# Altium schematic sheet HARRY.SchDoc
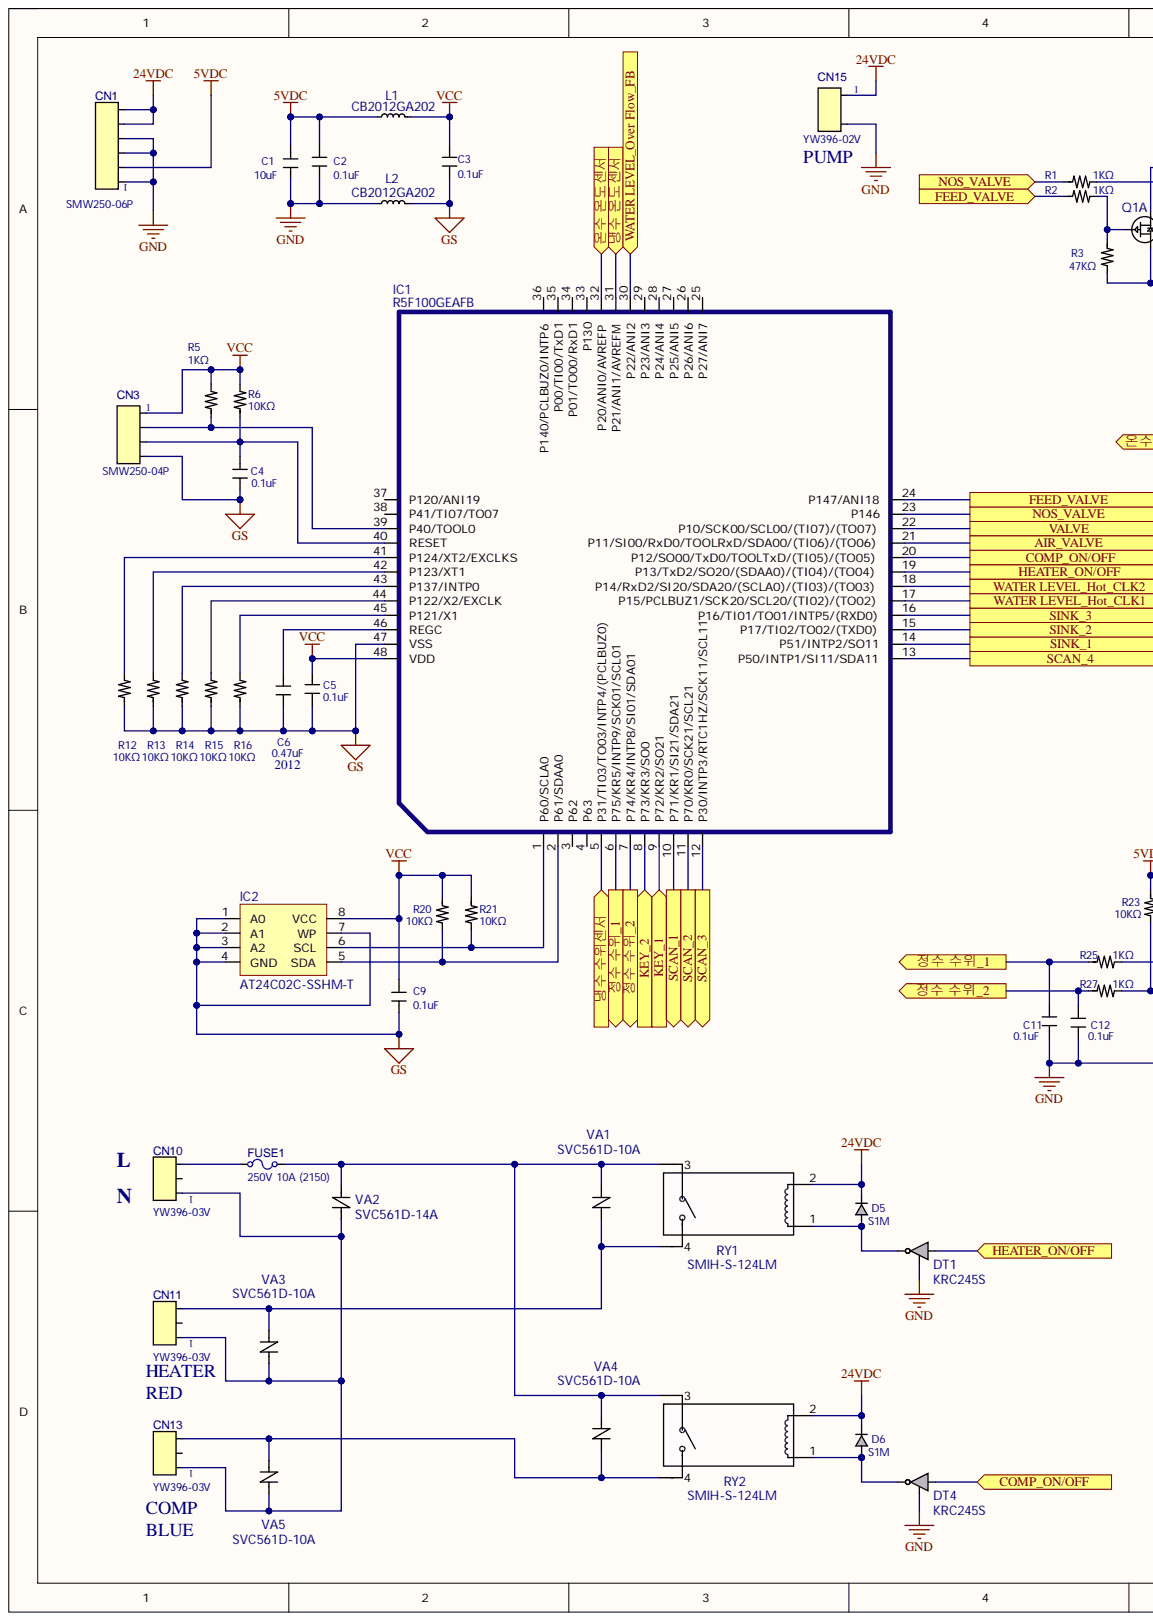
[diagram p0: HARRY.SchDoc, left]
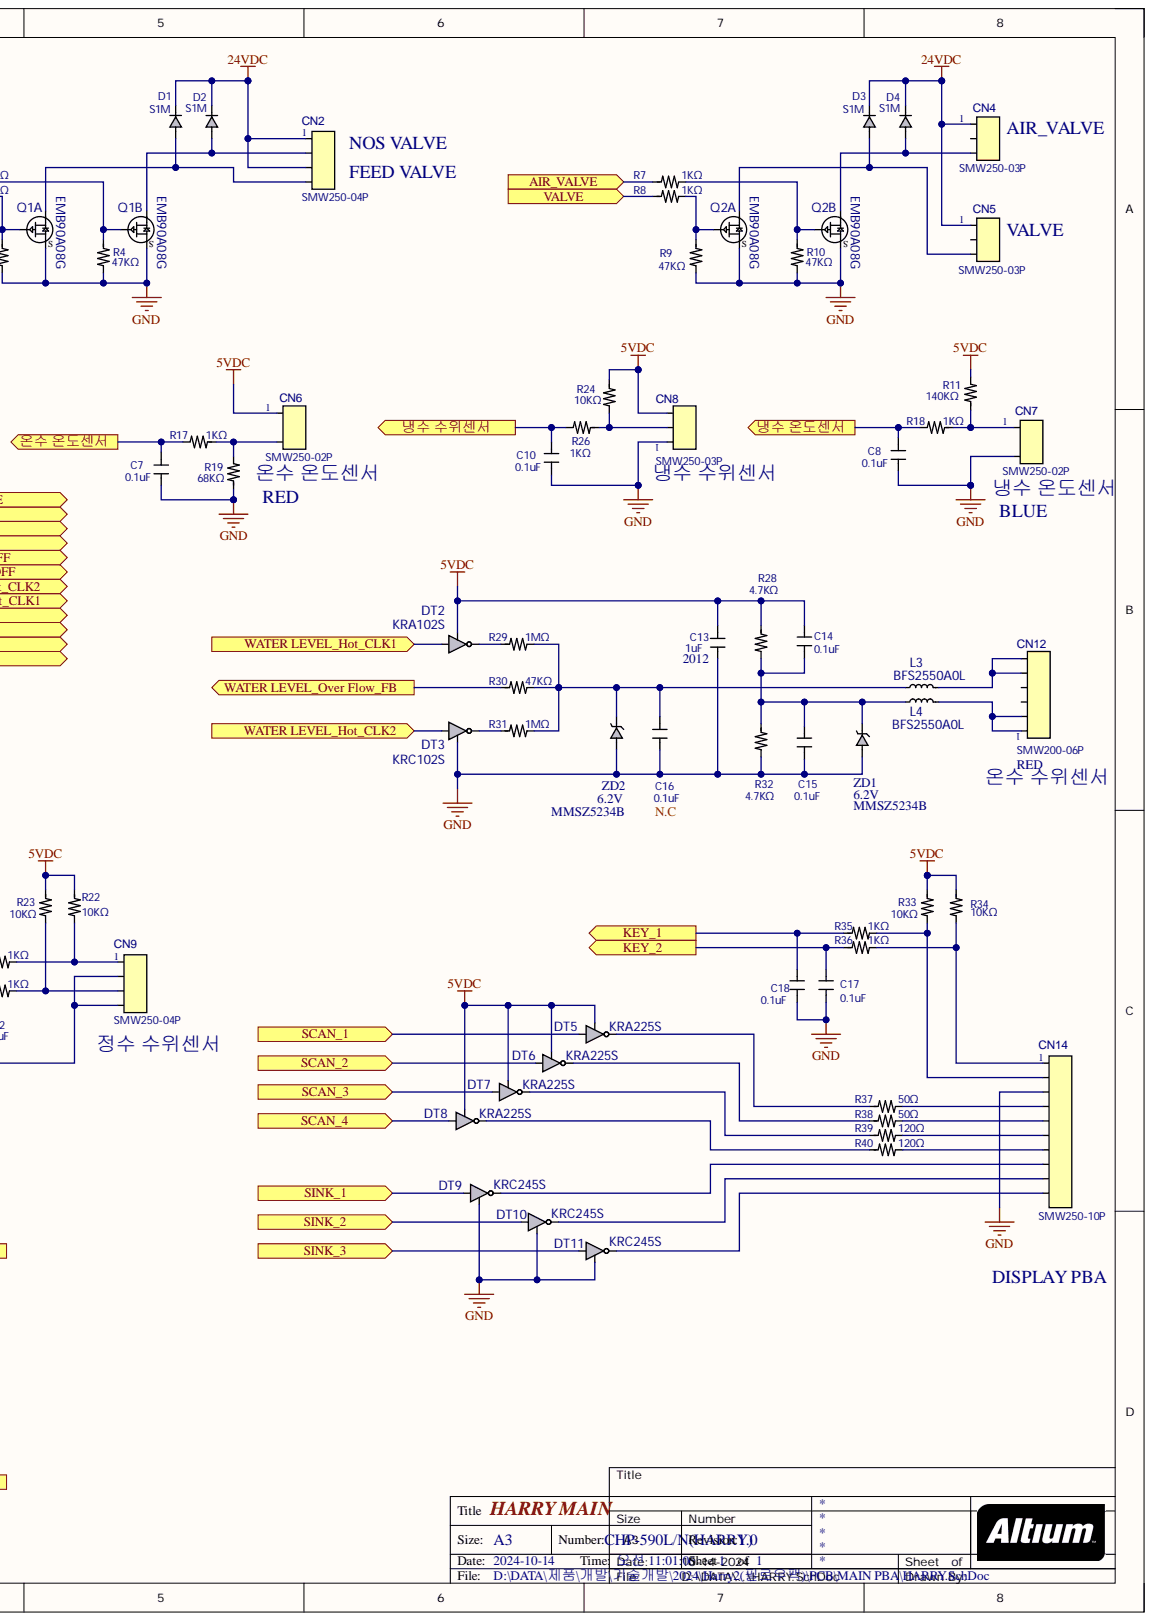
[diagram p1: HARRY.SchDoc, right]

## Parts
C1  10uF  [C-SMD-3216]
C2  0.1uF  [C-SMD-1608]
C3  0.1uF  [C-SMD-1608]
C4  0.1uF  [C-SMD-1608]
C5  0.1uF  [C-SMD-1608]
C6  0.47uF  [C-SMD-2012]
C7  0.1uF  [C-SMD-1608]
C8  0.1uF  [C-SMD-1608]
C9  0.1uF  [C-SMD-1608]
C10  0.1uF  [C-SMD-1608]
C11  0.1uF  [C-SMD-1608]
C12  0.1uF  [C-SMD-1608]
C13  1uF  13.PKG: 2012  [C-SMD-2012]
C14  0.1uF  [C-SMD-1608]
C15  0.1uF  [C-SMD-1608]
C16  0.1uF  [C-SMD-1608]
C17  0.1uF  [C-SMD-1608]
C18  0.1uF  [C-SMD-1608]
CN1  SMW250-06P  [CN-SMW250-06-06P-2_5P-174058-070H]
CN2  SMW250-04P  [CN-SMW250-04-04P-2_5P-124058-070H]
CN3  SMW250-04P  [CN-SMW250-04-04P-2_5P-124058-070H]
CN4  SMW250-03P  [CN-SMW250-03-03P-2_5P-099058-070H]
CN5  SMW250-03P  [CN-SMW250-03-03P-2_5P-099058-070H]
CN6  SMW250-02P  [CN-SMW250-02-02P-2_5P-074058-070H]
CN7  SMW250-02P  [CN-SMW250-02-02P-2_5P-074058-070H]
CN8  SMW250-03P  [CN-SMW250-03-03P-2_5P-099058-070H]
CN9  SMW250-04P  [CN-SMW250-04-04P-2_5P-124058-070H]
CN10  YW396-03V  [CN-YW396-03V-03P-3_96P-118081-111H]
CN11  YW396-03V  [CN-YW396-03V-03P-3_96P-118081-111H]
CN12  SMW200-06P  14.Color: RED  [CN-SMW200-6P-06P-2_0P-140051-077H]
CN13  YW396-03V  [CN-YW396-03V-03P-3_96P-118081-111H]
CN14  SMW250-10P  [CN-SMW250-10-10P-2_5P-274058-070H]
CN15  YW396-02V  [CN-YW396-02V-02P-3_96P-078081-111H]
D1  S1M  [D_DO-214AC]
D2  S1M  [D_DO-214AC]
D3  S1M  [D_DO-214AC]
D4  S1M  [D_DO-214AC]
D5  S1M  [D_DO-214AC]
D6  S1M  [D_DO-214AC]
DT1  KRC245S  [TR-SOT-23_BCE-3P-1_0P-031027-013H]
DT2  KRA102S  [TR-SOT-23_BCE-3P-1_0P-031027-013H]
DT3  KRC102S  [TR-SOT-23_BCE-3P-1_0P-031027-013H]
DT4  KRC245S  [TR-SOT-23_BCE-3P-1_0P-031027-013H]
DT5  KRA225S  [TR-SOT-23_BCE-3P-1_0P-031027-013H]
DT6  KRA225S  [TR-SOT-23_BCE-3P-1_0P-031027-013H]
DT7  KRA225S  [TR-SOT-23_BCE-3P-1_0P-031027-013H]
DT8  KRA225S  [TR-SOT-23_BCE-3P-1_0P-031027-013H]
DT9  KRC245S  [TR-SOT-23_BCE-3P-1_0P-031027-013H]
DT10  KRC245S  [TR-SOT-23_BCE-3P-1_0P-031027-013H]
DT11  KRC245S  [TR-SOT-23_BCE-3P-1_0P-031027-013H]
FUSE1  250V 10A (2150)  [FUSE_20MM]
IC1  R5F100GEAFB  [IC-LQFP48P-0_48P]
IC2  AT24C02C-SSHM-T  [IC-SOP08P-1_27P-046058-175H]
L1  CB2012GA202  [L_2012SMD]
L2  CB2012GA202  [L_2012SMD]
L3  BFS2550A0L  [New footprint 1]
L4  BFS2550A0L  [New footprint 1]
Q1  EMB90A08G  [TR-SOP08P-1_27P-046058-175H]  (parts A,B)
Q2  EMB90A08G  [TR-SOP08P-1_27P-046058-175H]  (parts A,B)
R1  1KΩ  [R-SMD-1608-045H]
R2  1KΩ  [R-SMD-1608-045H]
R3  47KΩ  [R-SMD-1608-045H]
R4  47KΩ  [R-SMD-1608-045H]
R5  1KΩ  [R-SMD-1608-045H]
R6  10KΩ  [R-SMD-1608-045H]
R7  1KΩ  [R-SMD-1608-045H]
R8  1KΩ  [R-SMD-1608-045H]
R9  47KΩ  [R-SMD-1608-045H]
R10  47KΩ  [R-SMD-1608-045H]
R11  140KΩ  [R-SMD-1608-045H]
R12  10KΩ  [R-SMD-1608-045H]
R13  10KΩ  [R-SMD-1608-045H]
R14  10KΩ  [R-SMD-1608-045H]
R15  10KΩ  [R-SMD-1608-045H]
R16  10KΩ  [R-SMD-1608-045H]
R17  1KΩ  [R-SMD-1608-045H]
R18  1KΩ  [R-SMD-1608-045H]
R19  68KΩ  [R-SMD-1608-045H]
R20  10KΩ  [R-SMD-1608-045H]
R21  10KΩ  [R-SMD-1608-045H]
R22  10KΩ  [R-SMD-1608-045H]
R23  10KΩ  [R-SMD-1608-045H]
R24  10KΩ  [R-SMD-1608-045H]
R25  1KΩ  [R-SMD-1608-045H]
R26  1KΩ  [R-SMD-1608-045H]
R27  1KΩ  [R-SMD-1608-045H]
R28  4.7KΩ  [R-SMD-1608-045H]
R29  1MΩ  [R-SMD-1608-045H]
R30  47KΩ  [R-SMD-1608-045H]
R31  1MΩ  [R-SMD-1608-045H]
R32  4.7KΩ  [R-SMD-1608-045H]
R33  10KΩ  [R-SMD-1608-045H]
R34  10KΩ  [R-SMD-1608-045H]
R35  1KΩ  [R-SMD-1608-045H]
R36  1KΩ  [R-SMD-1608-045H]
R37  50Ω  [R-SMD-3216-055H]
R38  50Ω  [R-SMD-3216-055H]
R39  120Ω  [R-SMD-3216-055H]
R40  120Ω  [R-SMD-3216-055H]
RY1  SMIH-S-124LM  [OMIH-SS-124LM]
RY2  SMIH-S-124LM  [OMIH-SS-124LM]
VA1  SVC561D-10A  [ZNR_D10]
VA2  SVC561D-14A  [ZNR_D14]
VA3  SVC561D-10A  [ZNR_D10]
VA4  SVC561D-10A  [ZNR_D10]
VA5  SVC561D-10A  [ZNR_D10]
ZD1  MMSZ5234B  11.Vz: 6.2V  [MMSZ5234B-Footprint-1]
ZD2  MMSZ5234B  [MMSZ5234B-Footprint-1]

## Ports
AIR_VALVE, COMP_ON/OFF, FEED_VALVE, HEATER_ON/OFF, KEY_1, KEY_2, NOS_VALVE, SCAN_1, SCAN_2, SCAN_3, SCAN_4, SINK_1, SINK_2, SINK_3, VALVE, WATER LEVEL_Hot_CLK1, WATER LEVEL_Hot_CLK2, WATER LEVEL_Over Flow_FB, 냉수 수위센서, 냉수 온도센서, 온수 온도센서, 정수 수위_1, 정수 수위_2

## Nets
5VDC: C1.2 C2.1 C13.2 C14.1 CN1.2 CN6.1 CN8.3 DT2.E DT5.E DT6.E DT7.E DT8.E L1.1 R11.2 R22.1 R23.1 R24.1 R28.1 R33.1 R34.1
24VDC: CN1.5 CN1.6 CN2.1 CN2.3 CN4.1 CN5.1 CN15.1 D1.C D2.C D3.C D4.C D5.C D6.C RY1.2 RY2.2
GND: C1.1 C2.2 C7.1 C8.1 C10.2 C11.2 C12.1 C13.1 C15.2 C16.1 C17.2 C18.2 CN1.1 CN1.3 CN1.4 CN7.2 CN8.1 CN9.2 CN9.4 CN14.3 CN15.2 DT1.E DT3.E DT4.E DT9.E DT10.E DT11.E L2.1 Q1.1 Q1.3 Q2.1 Q2.3 R3.2 R4.2 R9.2 R10.2 R19.1 R32.2 ZD1.A ZD2.A
GS: C3.2 C4.1 C5.2 C6.1 C9.1 CN3.4 IC1.47(VSS) IC2.1(A0) IC2.2(A1) IC2.3(A2) IC2.4(GND) IC2.7(WP) L2.2 R12.1 R13.1 R14.1 R15.1 R16.1
VCC: C3.1 C5.1 C9.2 CN3.1 IC1.48(VDD) IC2.8(VCC) L1.2 R5.2 R6.2 R20.1 R21.1
AIR_VALVE: IC1.21(P11/SI00/RxD0/TOOLRxD/SDA00/(TI06)/(TO06)) R7.1 ; port AIR_VALVE
COMP_ON/OFF: DT4.B IC1.20(P12/SO00/TxD0/TOOLTxD/(TI05)/(TO05)) ; port COMP_ON/OFF
FEED_VALVE: IC1.24(P147/ANI18) R2.1 ; port FEED_VALVE
HEATER_ON/OFF: DT1.B IC1.19(P13/TxD2/SO20/(SDAA0)/(TI04)/(TO04)) ; port HEATER_ON/OFF
KEY_1: C18.1 IC1.9(P72/KR2/SO21) R35.1 ; port KEY_1
KEY_2: C17.1 IC1.8(P73/KR3/SO0) R36.1 ; port KEY_2
NOS_VALVE: IC1.23(P146) R1.1 ; port NOS_VALVE
SCAN_1: DT5.B IC1.10(P71/KR1/SI21/SDA21) ; port SCAN_1
SCAN_2: DT6.B IC1.11(P70/KR0/SCK21/SCL21) ; port SCAN_2
SCAN_3: DT7.B IC1.12(P30/INTP3/RTC1HZ/SCK11/SCL11) ; port SCAN_3
SCAN_4: DT8.B IC1.13(P50/INTP1/SI11/SDA11) ; port SCAN_4
SINK_1: DT9.B IC1.14(P51/INTP2/SO11) ; port SINK_1
SINK_2: DT10.B IC1.15(P17/TI02/TO02/(TXD0)) ; port SINK_2
SINK_3: DT11.B IC1.16(P16/TI01/TO01/INTP5/(RXD0)) ; port SINK_3
VALVE: IC1.22(P10/SCK00/SCL00/(TI07)/(TO07)) R8.1 ; port VALVE
WATER LEVEL_Hot_CLK1: DT2.B IC1.17(P15/PCLBUZ1/SCK20/SCL20/(TI02)/(TO02)) ; port WATER LEVEL_Hot_CLK1
WATER LEVEL_Hot_CLK2: DT3.B IC1.18(P14/RxD2/SI20/SDA20/(SCLA0)/(TI03)/(TO03)) ; port WATER LEVEL_Hot_CLK2
WATER LEVEL_Over Flow_FB: IC1.30(P22/ANI2) R30.1 ; port WATER LEVEL_Over Flow_FB
정수 수위_1: C11.1 IC1.6(P75/KR5/INTP9/SCK01/SCL01) R25.1 ; port 정수 수위_1
정수 수위_2: C12.2 IC1.7(P74/KR4/INTP8/SI01/SDA01) R27.1 ; port 정수 수위_2
NetC4_2: C4.2 CN3.3 IC1.40(RESET) R6.1
NetC6_2: C6.2 IC1.46(REGC)
NetC7_2: C7.2 IC1.32(P20/ANI0/AVREFP) R17.2
NetC8_2: C8.2 IC1.31(P21/ANI1/AVREFM) R18.2
NetC10_1: C10.1 IC1.5(P31/TI03/TO03/INTP4/(PCLBUZ0)) R26.2
NetC14_2: C14.2 C15.1 L4.1 R28.2 R32.1 ZD1.C
NetC16_2: C16.2 L3.1 R29.2 R30.2 R31.2 ZD2.C
NetCN2_2: CN2.2 D2.A Q1.5
NetCN2_4: CN2.4 D1.A Q1.8
NetCN3_2: CN3.2 IC1.39(P40/TOOL0) R5.1
NetCN4_3: CN4.3 D4.A Q2.5
NetCN5_3: CN5.3 D3.A Q2.8
NetCN6_2: CN6.2 R17.1 R19.2
NetCN7_1: CN7.1 R11.1 R18.1
NetCN8_2: CN8.2 R24.2 R26.1
NetCN9_1: CN9.1 R22.2 R25.2
NetCN9_3: CN9.3 R23.2 R27.2
NetCN10_1: CN10.1 CN11.1 CN13.1 VA2.2 VA3.1 VA5.1
NetCN10_3: CN10.3 FUSE1.1
NetCN11_3: CN11.3 RY1.4 VA1.1 VA3.2
NetCN12_1: CN12.1 CN12.2 L4.2
NetCN12_5: CN12.5 CN12.6 L3.2
NetCN13_3: CN13.3 RY2.4 VA4.1 VA5.2
NetCN14_1: CN14.1 R34.2 R36.2
NetCN14_2: CN14.2 R33.2 R35.2
NetCN14_4: CN14.4 R37.2
NetCN14_5: CN14.5 R38.2
NetCN14_6: CN14.6 R39.2
NetCN14_7: CN14.7 R40.2
NetCN14_8: CN14.8 DT9.C
NetCN14_9: CN14.9 DT10.C
NetCN14_10: CN14.10 DT11.C
NetD5_A: D5.A DT1.C RY1.1
NetD6_A: D6.A DT4.C RY2.1
NetDT2_C: DT2.C R29.1
NetDT3_C: DT3.C R31.1
NetDT5_C: DT5.C R37.1
NetDT6_C: DT6.C R38.1
NetDT7_C: DT7.C R39.1
NetDT8_C: DT8.C R40.1
NetFUSE1_2: FUSE1.2 RY1.3 RY2.3 VA1.2 VA2.1 VA4.2
NetIC1_1: IC1.1(P60/SCLA0) IC2.6(SCL) R21.2
NetIC1_2: IC1.2(P61/SDAA0) IC2.5(SDA) R20.2
NetIC1_41: IC1.41(P124/XT2/EXCLKS) R12.2
NetIC1_42: IC1.42(P123/XT1) R13.2
NetIC1_43: IC1.43(P137/INTP0) R14.2
NetIC1_44: IC1.44(P122/X2/EXCLK) R15.2
NetIC1_45: IC1.45(P121/X1) R16.2
NetQ1_2: Q1.2 R2.2 R3.1
NetQ1_4: Q1.4 R1.2 R4.1
NetQ2_2: Q2.2 R8.2 R9.1
NetQ2_4: Q2.4 R7.2 R10.1

Not connected: CN4 2; CN5 2; CN10 2; CN11 2; CN12 3 4; CN13 2; IC1 3(P62) 4(P63) 25(P27/ANI7) 26(P26/ANI6) 27(P25/ANI5) 28(P24/ANI4) 29(P23/ANI3) 33(P130) 34(P01/TO00/RxD1) 35(P00/TI00/TxD1) 36(P140/PCLBUZ0/INTP6) 37(P120/ANI19) 38(P41/TI07/TO07)

## Library data of the parts
C1 = CMP-00585-2: 10uF,25V,3216,125℃,+10, -10%,,Reel,; 02.Part Number: 8026573; 01.No: 26432; 03.부품분류: CAPACITOR, MLCC; 13.PKG: 3216; 15.C Tolerance: 10; 11.Capacitance: 10uF; 12.Voltage: 25V; 14.Temperature: 125℃; 16.Pitch: -10%; 21.Value: 10uF; 22.등록일: 2014-02-28; Component Kind: Standard; Library Name: Coway 365
C2 C3 C5 = CMP-00596-2: 0.1uF,50V,1608,125℃,+10, -10%,,Reel,; 02.Part Number: 8026584; 01.No: 26443; 03.부품분류: CAPACITOR, MLCC; 13.PKG: 1608; 15.C Tolerance: 10; 11.Capacitance: 0.1uF; 12.Voltage: 50V; 14.Temperature: 125℃; 16.Pitch: -10%; 21.Value: 0.1uF; 22.등록일: 2014-02-28; Component Kind: Standard; Library Name: <Coway> Concord
C4 C7-C12 C14 C15 C17 C18 = CMP-00566-2: 0.1uF,50V,1608,125℃,+10, -10%,,Reel,; 02.Part Number: 8026554; 01.No: 26413; 03.부품분류: CAPACITOR, MLCC; 13.PKG: 1608; 15.C Tolerance: 10; 11.Capacitance: 0.1uF; 12.Voltage: 50V; 14.Temperature: 125℃; 16.Pitch: -10%; 21.Value: 0.1uF; 22.등록일: 2014-02-28; Component Kind: Standard
  C4: Library Name: <Coway> Concord
  C7-C12 C14 C15 C17 C18: Library Name: Coway 365
C6 = CMP-00523-2: 0.47uF,50V,2012,125℃,+10,-10%,,Reel,; 02.Part Number: 8026191; 01.No: 26054; 03.부품분류: CAPACITOR, MLCC; 13.PKG: 2012; 15.C Tolerance: 10; 11.Capacitance: 0.47uF; 12.Voltage: 50V; 14.Temperature: 125℃; 16.Pitch: -10%; 21.Value: 0.47uF; 22.등록일: 2014-02-28; Component Kind: Standard; Library Name: <Coway> Concord
C13 = CMP-00528-2: 1uF,50V,2012,125℃,+10, -10%,,Reel,; 02.Part Number: 8026199; 01.No: 26062; 03.부품분류: CAPACITOR, MLCC; 15.C Tolerance: 10; 11.Capacitance: 1uF; 12.Voltage: 50V; 14.Temperature: 125℃; 16.Pitch: -10%; 21.Value: 1uF; 22.등록일: 2014-02-28; Component Kind: Standard; Library Name: <Coway> Concord
C16 = CMP-00596-2: 0.1uF,50V,1608,125℃,+10, -10%,,Reel,; 02.Part Number: 8026584; 01.No: 26443; 03.부품분류: CAPACITOR, MLCC; 13.PKG: 1608; 15.C Tolerance: 10; 18.Etc: N.C; 11.Capacitance: 0.1uF; 12.Voltage: 50V; 14.Temperature: 125℃; 16.Pitch: -10%; 21.Value: 0.1uF; 22.등록일: 2014-02-28; Component Kind: Standard; Library Name: <Coway> Concord
CN1 = CMP-01008-4: straight,6,2.5,,250,3,; 02.Part Number: 8027199; 01.No: 26940; 03.부품분류: CONNECTOR, DIP; 12.No. of Pin: 6; 13.Pitch: 2.5; 15.I Max: 250; 16.Height: 3; 11Type: straight; 14.Color: -; 21.Value: SMW250-06P; 22.등록일: 2014-02-28; Component Kind: Standard; Library Name: Coway 365
CN2 CN3 CN9 = CMP-01006-3: straight,4,2.5,,250,3,; 02.Part Number: 8027197; 01.No: 26938; 03.부품분류: CONNECTOR, DIP; 12.No. of Pin: 4; 13.Pitch: 2.5; 15.I Max: 250; 16.Height: 3; 11Type: straight; 14.Color: -; 21.Value: SMW250-04P; 22.등록일: 2014-02-28; Component Kind: Standard; Library Name: Coway 365
CN4 CN5 = CMP-01005-2: straight,3,2.5,,250,3,; 02.Part Number: 8027196; 01.No: 26937; 03.부품분류: CONNECTOR, DIP; 12.No. of Pin: 3; 13.Pitch: 2.5; 15.I Max: 250; 11Type: straight; 14.Color: -; 16.Height: 3; 21.Value: SMW250-03P; 22.등록일: 2014-02-28; Component Kind: Standard; Library Name: Coway 365
CN6 CN7 = CMP-01004-4: straight,2,2.5,,250,3,; 02.Part Number: 8027195; 01.No: 26936; 03.부품분류: CONNECTOR, DIP; 12.No. of Pin: 2; 13.Pitch: 2.5; 15.I Max: 250; 16.Height: 3; 11Type: straight; 14.Color: -; 21.Value: SMW250-02P; 22.등록일: 2014-02-28; Component Kind: Standard; Library Name: Coway 365
CN8 = CMP-01005-3: straight,3,2.5,,250,3,; 02.Part Number: 8027196; 01.No: 26937; 03.부품분류: CONNECTOR, DIP; 12.No. of Pin: 3; 13.Pitch: 2.5; 15.I Max: 250; 11Type: straight; 14.Color: -; 16.Height: 3; 21.Value: SMW250-03P; 22.등록일: 2014-02-28; Component Kind: Standard; Library Name: Coway 365
CN10 CN11 CN13 = CMP-00904-3: straight,3,3.96,,250,7.5,; 02.Part Number: 8027095; 01.No: 26836; 03.부품분류: CONNECTOR, DIP; 12.No. of Pin: 3; 13.Pitch: 3.96; 15.I Max: 250; 16.Height: 7.5; 11Type: straight; 14.Color: -; 21.Value: YW396-03V; 22.등록일: 2014-02-28; Component Kind: Standard; Library Name: Coway 365
CN12 = CMP-01038-2: straight,6,2,,250,3,; 02.Part Number: 8027229; 01.No: 26970; 03.부품분류: CONNECTOR, DIP; 12.No. of Pin: 6; 13.Pitch: 2; 15.I Max: 250; 16.Height: 3; 11Type: straight; 21.Value: SMW200-06P; 22.등록일: 2014-02-28; Component Kind: Standard; Library Name: <Coway> Concord
CN14 = CMP-01012-3: straight,10,2.5,,250,3,; 02.Part Number: 8027203; 01.No: 26944; 03.부품분류: CONNECTOR, DIP; 12.No. of Pin: 10; 13.Pitch: 2.5; 15.I Max: 250; 16.Height: 3; 11Type: straight; 14.Color: -; 21.Value: SMW250-10P; 22.등록일: 2014-02-28; Component Kind: Standard; Library Name: Coway 365
CN15 = CMP-00903-2: straight,2,3.96,,250,7.5A,; 02.Part Number: 8027094; 01.No: 26835; 03.부품분류: CONNECTOR, DIP; 12.No. of Pin: 2; 13.Pitch: 3.96; 15.I Max: 250; 11Type: straight; 14.Color: -; 16.Height: 7.5A; 21.Value: YW396-02V; 22.등록일: 2014-02-28; Component Kind: Standard; Library Name: Coway 365
D1-D6 = CMP-00066-2: 1000V,1A,1.1V,DO-214AC,,; 02.Part Number: 8025325; 01.No: 78; 03.부품분류: DIODE, RECTIFIER; 11.Vr: 1000V; 12.IF: 1A; 13.Power: 1.1V; 14.PKG: DO-214AC; 21.Value: S1M; 22.등록일: 2015-01-29; Component Kind: Standard; Library Name: <Coway> Concord
DT1 DT4 = CMP-27504-1: NPN,50V,0.8A,SOT-23,0.2W,56,1K/10K,; 02.Part Number: 8025514; 01.No: 25664; 03.부품분류: TR, DIGITAL; 16.hfe: 56; 11.Channel: NPN; 12.Max VCE: 50V; 13.Max IC: 0.8A; 14.PKG: SOT-23; 15.Power: 0.2W; 17.R2/R1: 1K/10K; 21.Value: KRC245S; 22.등록일: 2014-02-28; Component Kind: Standard; Library Name: <Coway> Concord
DT2 = CMP-27485-1: PNP,-50V,-0.1A,SOT-23,0.2W,80,10K/10K,; 02.Part Number: 8025563; 01.No: 25713; 03.부품분류: TR, DIGITAL; 16.hfe: 80; 23.신뢰성: ok; 11.Channel: PNP; 12.Max VCE: -50V; 13.Max IC: -0.1A; 14.PKG: SOT-23; 15.Power: 0.2W; 17.R2/R1: 10K/10K; 21.Value: KRA102S; 22.등록일: 2014-02-28; Component Kind: Standard; Library Name: <Coway> Concord
DT3 = CMP-27487-1: NPN,50V,0.1A,SOT-23,0.2W,80,10K/10K,; 02.Part Number: 8025561; 01.No: 25711; 03.부품분류: TR, DIGITAL; 16.hfe: 80; 23.신뢰성: ok; 11.Channel: NPN; 12.Max VCE: 50V; 13.Max IC: 0.1A; 14.PKG: SOT-23; 15.Power: 0.2W; 17.R2/R1: 10K/10K; 21.Value: KRC102S; 22.등록일: 2014-02-28; Component Kind: Standard; Library Name: <Coway> Concord
DT5-DT8 = CMP-27501-2: PNP,-50V,-0.8A,SOT-23,0.2W,56,1K/10K,; 02.Part Number: 8025525; 01.No: 25675; 03.부품분류: TR, DIGITAL; 16.hfe: 56; 23.신뢰성: ok; 11.Channel: PNP; 12.Max VCE: -50V; 13.Max IC: -0.8A; 14.PKG: SOT-23; 15.Power: 0.2W; 17.R2/R1: 1K/10K; 21.Value: KRA225S; 22.등록일: 2014-02-28; Component Kind: Standard; Library Name: Coway 365
DT9-DT11 = CMP-27504-2: NPN,50V,0.8A,SOT-23,0.2W,56,1K/10K,; 02.Part Number: 8025514; 01.No: 25664; 03.부품분류: TR, DIGITAL; 16.hfe: 56; 11.Channel: NPN; 12.Max VCE: 50V; 13.Max IC: 0.8A; 14.PKG: SOT-23; 15.Power: 0.2W; 17.R2/R1: 1K/10K; 21.Value: KRC245S; 22.등록일: 2014-02-28; Component Kind: Standard; Library Name: Coway 365
FUSE1 = CMP-01936-2: 250,10,Time_Lag,,20±0.5,; 02.Part Number: 8027031; 01.No: 26796; 03.부품분류: PROTECTION DEVICE, FUSE; 11.Rated Voltage: 250; 12.Rated Current: 10; 13.MELTING TYPE: Time_Lag; 14.Meterial: -; 15.Dimension: 20±0.5; 21.Value: 250V 10A (2150); 22.등록일: 2014-02-28; Component Kind: Standard; Library Name: Coway 365
IC1 = CMP-00644-2: 48P,10P,44P,LFQFP,bit,bits,℃,; 02.Part Number: 8028492; 01.No: 5; 03.부품분류: IC, MICOM; 23.신뢰성: ok; 11.No. of Pin: 48P; 12.No. of ADC: 10P; 13.No. of IO PIN: 44P; 14.PKG: LFQFP; 15.ROM Size: bit; 16.RAM Size: bits; 17.t Max: ℃; 21.Value: R5F100GEAFB; 22.등록일: 2018-02-13; Component Kind: Standard; Library Name: <Coway> Concord
IC2 = CMP-00811-2: 6.25,5,,,125,,; 02.Part Number: 8025728; 01.No: 25811; 03.부품분류: IC, EEPROM; 11.V Max: 6.25; 12.I Max: 5; 15.t Max: 125; 13.ROM Size: -; 14.PKG: -; 21.Value: AT24C02C-SSHM-T; 22.등록일: 2014-02-28; Component Kind: Standard; Library Name: Coway 365
L1 L2 = CMP-01487-1: ,,,,,,; 02.Part Number: 8026661; 01.No: 26502; 03.부품분류: INDUCTOR, AXIAL; 11.Inductance: -; 12.Tolerance: -; 13.Current: -; 14.Dimension: -; 22.등록일: 2014-02-28; Component Kind: Standard; Library Name: <Coway> Concord
L3 L4 = CMP-27614-1: BEAD, AXIAL, 80Ω, 6A; 03.부품분류: INDUCTOR, AXIAL; 15.Temperature: 105℃; 21.Value: HBA3565PL; 11.Inductance: 80Ω; 12.Tolerance: -; 13.Current: 6A; 14.Dimension: 3.5X6.5; 16.Packing Type: AXIAL; 22.등록일: 2021-04-26; Component Kind: Standard; Library Name: <Coway> Concord
Q1 Q2 = CMP-27533-1: N,80V,4500mA,SOP-8,85mΩ,20V,; 02.Part Number: 8028225; 01.No: 60; 03.부품분류: TR, FET; 23.신뢰성: ok; 11.Channel: N; 12.Max VCS: 80V; 13.Max Id: 4500mA; 14.PKG: SOP-8; 15.RDS: 85mΩ; 16.VGS: 20V; 21.Value: EMB90A08G; 22.등록일: 2015-07-24; Component Kind: Standard; Library Name: <Coway> Concord
R1-R4 R7-R10 R20-R23 R33 R34 = CMP-24436-2: 10KΩ,1/10W,J,1608,,; 02.Part Number: 8002135; 01.No: 2295; 03.부품분류: RESISTOR, SMD; 14.PKG: 1608; 11.Resistance: 10KΩ; 12.Power: 1/10W; 13.Tolerance: J; 21.Value: 10KΩ; 22.등록일: 2014-02-28; Component Kind: Standard; Library Name: <Coway> Concord
R5 R6 R11-R19 R24-R27 R35 R36 = CMP-25460-2: 10KΩ,1/10W,J,1608,,; 02.Part Number: 8003159; 01.No: 3319; 03.부품분류: RESISTOR, SMD; 14.PKG: 1608; 11.Resistance: 10KΩ; 12.Power: 1/10W; 13.Tolerance: J; 21.Value: 10KΩ; 22.등록일: 2014-02-28; Component Kind: Standard; Library Name: Coway 365
R28 R32 = CMP-25460-2: 4.7KΩ,1/10W,J,1608,,; 02.Part Number: 8003159; 01.No: 3319; 03.부품분류: RESISTOR, SMD; 14.PKG: 1608; 11.Resistance: 4.7KΩ; 12.Power: 1/10W; 13.Tolerance: J; 21.Value: 4.7KΩ; 22.등록일: 2014-02-28; Component Kind: Standard; Library Name: Coway 365
R29 R31 = CMP-25460-2: 1MΩ,1/10W,J,1608,,; 02.Part Number: 8003159; 01.No: 3319; 03.부품분류: RESISTOR, SMD; 14.PKG: 1608; 11.Resistance: 1MΩ; 12.Power: 1/10W; 13.Tolerance: J; 21.Value: 1MΩ; 22.등록일: 2014-02-28; Component Kind: Standard; Library Name: Coway 365
R30 = CMP-25460-2: 47KΩ,1/10W,J,1608,,; 02.Part Number: 8003159; 01.No: 3319; 03.부품분류: RESISTOR, SMD; 14.PKG: 1608; 11.Resistance: 47KΩ; 12.Power: 1/10W; 13.Tolerance: J; 21.Value: 47KΩ; 22.등록일: 2014-02-28; Component Kind: Standard; Library Name: Coway 365
R37-R40 = CMP-23120-2: 1.1MΩ,1/4W,J,3216,,; 02.Part Number: 8000819; 01.No: 979; 03.부품분류: RESISTOR, SMD; 14.PKG: 3216; 11.Resistance: 1.1MΩ; 12.Power: 1/4W; 13.Tolerance: J; 21.Value: 1.1MΩ; 22.등록일: 2014-02-28; Component Kind: Standard; Library Name: Coway 365
RY1 RY2 = CMP-02188-2: ,,,,,,; 02.Part Number: 8026758; 01.No: 26550; 03.부품분류: RELAY, A CONTACT; 23.신뢰성: ok; 11.V DC: -; 12.V AC: -; 13.Contact Allowable Current: -; 14.Coil Resistance: -; 21.Value: SMIH-S-124LM; 22.등록일: 2014-02-28; Component Kind: Standard; Library Name: <Coway> Concord
VA1 VA3-VA5 = CMP-01440-2: 560,2500,10,10mm,14.0x7.5mm; 02.Part Number: 8026804; 01.No: 26571; 03.부품분류: PROTECTION DEVICE, VARISTOR; 11.V Max: 560; 12.I peak: 2500; 13.PI: 10; 14.Dimension: 10mm; 15.Etc: 14.0x7.5mm; 21.Value: SVC561D-10A; 22.등록일: 2014-02-28; Component Kind: Standard; Library Name: <Coway> Concord
VA2 = CMP-01441-2: 560,4500,14,10mm,17.5x7.5mm; 02.Part Number: 8026805; 01.No: 26572; 03.부품분류: PROTECTION DEVICE, VARISTOR; 11.V Max: 560; 12.I peak: 4500; 13.PI: 14; 14.Dimension: 10mm; 15.Etc: 17.5x7.5mm; 21.Value: SVC561D-14A; 22.등록일: 2014-02-28; Component Kind: Standard; Library Name: <Coway> Concord
ZD1 ZD2 = CMP-01500-1: Zener Diode, 6.2V V(Z), 5%, 0.5W; 03.부품분류: DIODE,ZENER; 12.Power: 500Mw; 13.PKG: SOD-123; 14.Tolerance: 5%; 21.Value: MMSZ5234B; Component Kind: Standard; Max Operating Temperature: 150°C; Max Power Dissipation: 500mW; Min Operating Temperature: -65°C; Number of Pins: 2; Packaging: Cut Tape; Power Rating: 500mW; REACH SVHC: No SVHC; Zener Voltage: 6.2V; Library Name: <Coway> Concord
  ZD2: 11.Vz: 6.2V
